# Altium schematic sheet Switch Panel.SchDoc
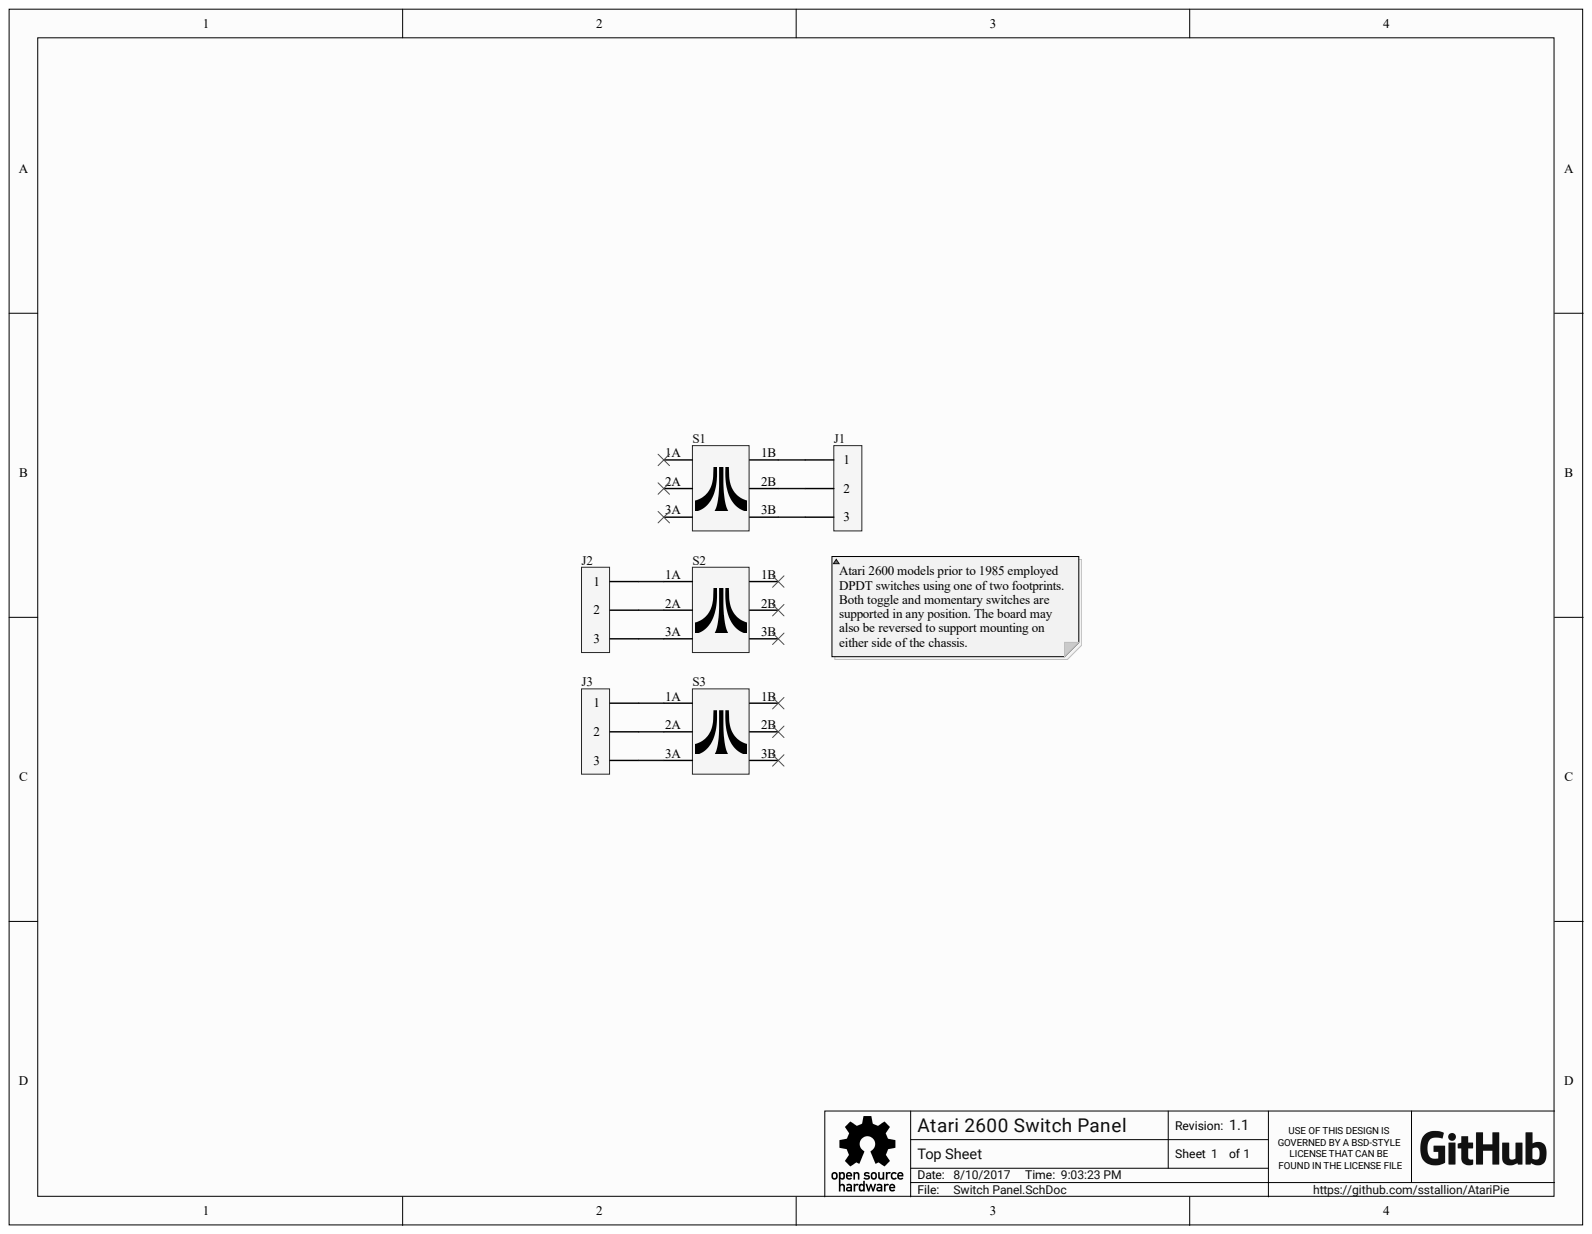
[diagram p0: Switch Panel.SchDoc]

## Parts
J1  Switch Header  [HDR1X3]  (value not drawn)
J2  Switch Header  [HDR1X3]  (value not drawn)
J3  Switch Header  [HDR1X3]  (value not drawn)
S1  SWITCH  [SWITCH]  (value not drawn)  (jumper)
S2  SWITCH  [SWITCH]  (value not drawn)  (jumper)
S3  SWITCH  [SWITCH]  (value not drawn)  (jumper)

## Nets
NetJ1_1: J1.1 S1.1B
NetJ1_2: J1.2 S1.2B
NetJ1_3: J1.3 S1.3B
NetJ2_1: J2.1 S2.1A
NetJ2_2: J2.2 S2.2A
NetJ2_3: J2.3 S2.3A
NetJ3_1: J3.1 S3.1A
NetJ3_2: J3.2 S3.2A
NetJ3_3: J3.3 S3.3A

Not connected: S1 1A 2A 3A; S2 1B 2B 3B; S3 1B 2B 3B

## Library data of the parts
S1-S3 = SWITCH: DPDT Switch; Library Name: Switch Panel.SchLib
J1-J3 = Switch Header: Component Kind: Standard; Library Name: Atari2600.SchLib
